# Altium schematic sheet Amplifier.SchDoc(Amplifier)
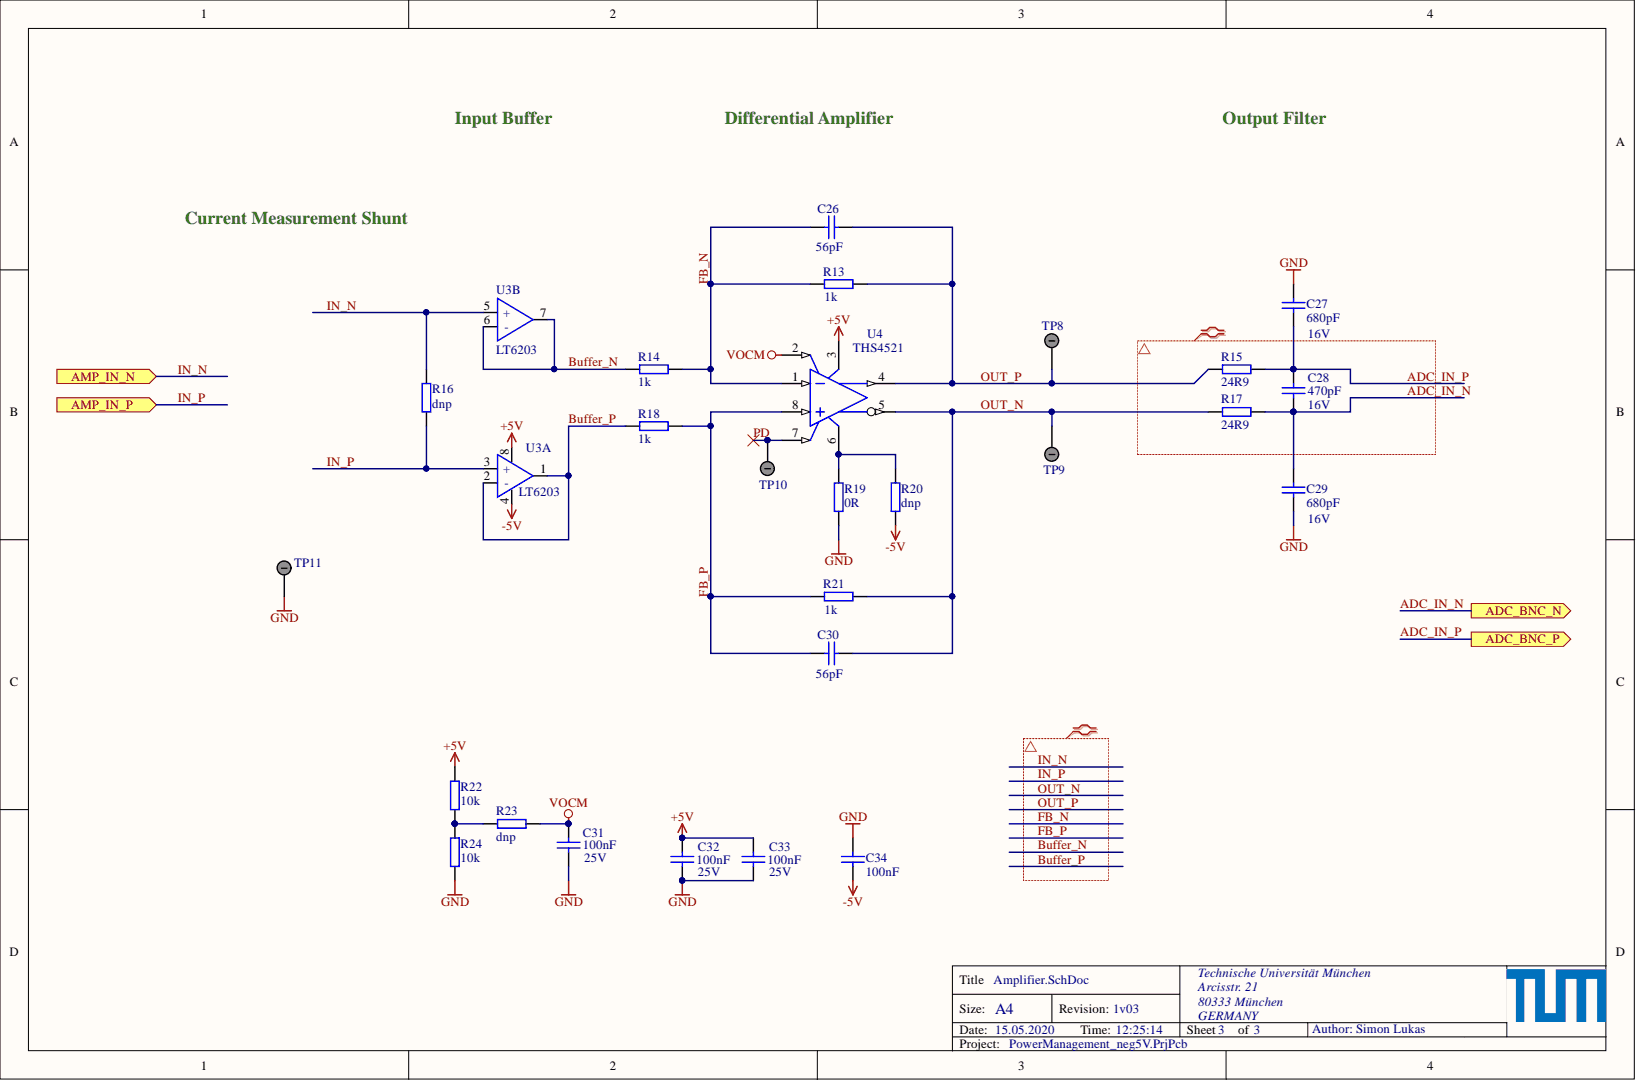
[diagram p0: Amplifier.SchDoc]

## Parts
C26  56pF  [CAPC1608X07N]
C27  680pF  Voltage: 16V  [CAPC1608X07N]
C28  470pF  Voltage: 16V  [CAPC1608X07N]
C29  680pF  Voltage: 16V  [CAPC1608X07N]
C30  56pF  [CAPC1608X07N]
C31  100nF  Voltage: 25V  [CAPC1608X07N]
C32  100nF  Voltage: 25V  [CAPC1608X07N]
C33  100nF  Voltage: 25V  [CAPC1608X07N]
C34  100nF  [CAPC1608X07N]
R13  1k  [RESC1608X06N]
R14  1k  [RESC1608X06N]
R15  24R9  [RESC1608X06N]
R16  dnp  [RESC3216X65N]
R17  24R9  [RESC1608X06N]
R18  1k  [RESC1608X06N]
R19  0R  [RESC1608X06N]
R20  dnp  [RESC1608X06N]
R21  1k  [RESC1608X06N]
R22  10k  [RESC1608X06N]
R23  dnp  [RESC1608X06N]
R24  10k  [RESC1608X06N]
TP8  AMP+  [TP_5006]  (value not drawn)
TP9  AMP-  [TP_5006]  (value not drawn)
TP10  PD  [TP_5006]
TP11  GND  [TP_5006]
U3  LT6203  [MSOP8_3x3-8N]  (parts A,B)
U4  THS4521  [DGK0008A_N]

## Ports
ADC_BNC_N, ADC_BNC_P, AMP_IN_N, AMP_IN_P

## Nets
+5V: C32.1 C33.1 R22.1 U3.8 U4.3
-5V: C34.2 R20.1 U3.4
GND: C27.1 C29.2 C31.2 C32.2 C33.2 C34.1 R19.1 R24.2 TP11.1
VOCM: C31.1 R23.1 U4.2
ADC_IN_N: C28.2 C29.1 R17.2 ; port ADC_BNC_N
ADC_IN_P: C27.2 C28.1 R15.2 ; port ADC_BNC_P
Buffer_N: R14.1 U3.6(-) U3.7
Buffer_P: R18.1 U3.1 U3.2(-)
FB_N: C26.1 R13.1 R14.2 U4.1
FB_P: C30.1 R18.2 R21.1 U4.8
IN_N: R16.2 U3.5(+) ; port AMP_IN_N
IN_P: R16.1 U3.3(+) ; port AMP_IN_P
OUT_N: C30.2 R17.1 R21.2 TP9.1 U4.5
OUT_P: C26.2 R13.2 R15.1 TP8.1 U4.4
PD: TP10.1 U4.7
NetR19_2: R19.2 R20.2 U4.6
NetR22_2: R22.2 R23.2 R24.1
N00012:
N00013:

## Library data of the parts
C26 C30 = Cap_0603: MPN: VJ0603A560JXACW1BC; Voltage: 50V; MF: Vishay; Dielectric: C0G; Value: 56pF; Supplier Part Number 1: 77-VJ0603A560JXACBC; Supplier 1: Mouser; Package: 0603; Component Kind: Standard; Library Name: neg5V.SCHLIB
C27 C29 = Cap_0603: MPN: VJ0603A681GXJCW1BC; MF: Vishay; Dielectric: C0G; Value: 680pF; Supplier Part Number 1: 77-VJ0603A681GXJCBC; Supplier 1: Mouser; Package: 0603; Component Kind: Standard; Library Name: neg5V.SCHLIB
C28 = Cap_0603: MPN: VJ0603A471JXJCW1BC; MF: Vishay; Dielectric: C0G; Value: 470pF; Supplier Part Number 1: 77-VJ0603A471JXJCBC; Supplier 1: Mouser; Package: 0603; Component Kind: Standard; Library Name: neg5V.SCHLIB
C31-C33 = Cap_0603: MPN: 885012206071; MF: Würth; Dielectric: X7R; Value: 100nF; Supplier Part Number 1: 710-885012206071; Supplier 1: Mouser; Package: 0603; Component Kind: Standard; Library Name: neg5V.SCHLIB
C34 = Cap_0603: MPN: 885012206071; Voltage: 25V; MF: Würth; Dielectric: X7R; Value: 100nF; Supplier Part Number 1: 710-885012206071; Supplier 1: Mouser; Package: 0603; Component Kind: Standard; Library Name: neg5V.SCHLIB
R13 R14 R18 R21 = R_0603: MPN: CRCW06031K00JNEAC; MF: Vishay / Dale; Value: 1k; Supplier Part Number 1: 71-CRCW06031K00JNEAC; Supplier 1: Mouser; Package: 0603; Component Kind: Standard; Library Name: neg5V.SCHLIB
R15 R17 = R_0603: MPN: RC0603FR-1324R9L; MF: Yageo; Value: 24R9; Supplier Part Number 1: 603-RC0603FR-1324R9L; Supplier 1: Mouser; Package: 0603; Component Kind: Standard; Library Name: neg5V.SCHLIB
R16 = R_1206: WID SMD 1M 5% 0,25W; MPN: APC1206B200RN; Preferred: Yes; possilbeValue: 200R; Mixed: SMT; MF: ARCOL/Ohmite; AN: 22757; Value: dnp; Tolerance: 5%; Technology: TK100; Supplier Part Number 1: 284-APC1206B200RN; Supplier 1: Mouser; Component Kind: Standard; Case: 1206; Library Name: neg5V.SCHLIB
R19 = R_0603: MPN: CRCW06030000Z0EBC; MF: Vishay / Dale; Value: 0R; Supplier Part Number 1: 71-CRCW06030000Z0EBC; Supplier 1: Mouser; Package: 0603; Component Kind: Standard; Library Name: neg5V.SCHLIB
R20 R23 = R_0603: Value: dnp; Package: 0603; Component Kind: Standard; Library Name: neg5V.SCHLIB
R22 R24 = R_0603: MPN: CRCW060310K0FKEAC; MF: Vishay / Dale; Value: 10k; Supplier Part Number 1: 71-CRCW060310K0FKEAC; Supplier 1: Mouser; Package: 0603; Component Kind: Standard; Library Name: neg5V.SCHLIB
TP8-TP11 = 5006: Test Point;  Compact;  THM;  PC;  .350 L;  .125 OD;  Heat Resistant Nylon 46;  Black; Manufacturer: Keystone Electronics; Plating: Silver; Outside Diameter: 3.18mm; Number of Ports: 1; Inside Diameter: 1.78mm; Hole Diameter: 1.6mm; Body Material: PBT; Supplier Part Number 1: 534-5006; Supplier 1: Mouser; Stock 1: 203116; RoHS: Yes; REACH SVHC: No SVHC; Packaging: Bulk; Package Quantity: 100; Orientation: Straight; Mount: PCB,Through Hole; Material: Nylon,Bronze,Silver; Manufacturer Part Number: 5006; Length: 8.89mm; Lead Free: Lead Free; Housing Color: Black; Height: 0.35inch; Flammability Rating: UL94 V-0; Contact Plating: Tin,Silver; Contact Material: Bronze; ComponentLink5URL: https://datasheet.ciiva.com/16883/70182825-16883963.pdf?src-supplier=Allied+Electronics; ComponentLink5Description: https://datasheet.ciiva.com/16883/70182825-16883963.pdf?src-supplier=Allied+Electronics; ComponentLink4URL: https://datasheet.ciiva.com/2917/m60-2p60-2917629.pdf?src-supplier=Digi-Key; ComponentLink4Description: https://datasheet.ciiva.com/2917/m60-2p60-2917629.pdf?src-supplier=Digi-Key; ComponentLink3URL: https://datasheet.ciiva.com/11744/0900766b8009b7c7-11744947.pdf?src-supplier=RSComponents; ComponentLink3Description: https://datasheet.ciiva.com/11744/0900766b8009b7c7-11744947.pdf?src-supplier=RSComponents; ComponentLink2URL: https://datasheet.ciiva.com/1454/1718283-1454829.pdf?src-supplier=Element14; ComponentLink2Description: https://datasheet.ciiva.com/1454/1718283-1454829.pdf?src-supplier=Element14; ComponentLink1URL: https://datasheet.ciiva.com/1123/m60-2p60-1123808.pdf?src-supplier=Newark; ComponentLink1Description: https://datasheet.ciiva.com/1123/m60-2p60-1123808.pdf?src-supplier=Newark; Component Kind: Standard; Color: Black; Category: Connectors; Library Name: neg5V.SCHLIB
U3 = LT6203_MSOP8: 2 Ch Low Noise, Low Power OpAmp; MPN: LT6203CMS8#PBF; MF: Analog Devices / Linear Technology; Supplier Part Number 1: 584-LT6203CMS8#PBF; Supplier 1: Mouser; Package: MSOP-8; Component Kind: Standard; Library Name: neg5V.SCHLIB; Created: Kreppel_20190830
U4 = THS4521IDGKR: IC AMP RRO NEG OUT VLP 8VSSOP (Single Channel fully differential Amplifier); Manufacturer: Texas Instruments; Voltage Gain: 119dB; Unity Gain Bandwidth Product: 95MHz; Slew Rate: 490V/µs; Power Supply Rejection Ratio (PSRR): 80dB; Output Current per Channel: 55mA; Operating Supply Voltage: 5V; Operating Supply Current: 1.14mA; Number of Elements: 1; Min Supply Voltage: 2.5V; Min Dual Supply Voltage: 1.5V; Max Supply Voltage: 5.5V; Max I/O Voltage: 2mV; Max Dual Supply Voltage: 2.75V; Input Bias Current: 900nA; Bandwidth: 145MHz; Weight: 0.000935oz; Supplier Part Number 1: 595-THS4521IDGKR; Supplier 1: Mouser; Stock 1: 12114; RoHS: Yes; REACH SVHC: No SVHC; Radiation Hardening: No; Pricing 1: 1=2,55000, 10=2,29000, 25=2,16000, 100=1,78000, 250=1,59000, 500=1,54000, 1000=1,28000, 2500=1,25000, 1=2,83000, 10=2,54000, 25=2,40000, 100=1,98000, 250=1,77000, 500=1,71000 (EUR); Packaging: Tape and Reel; Package Quantity: 2500; Number of Pins: 8; Number of Circuits: 1; Number of Channels: 1; Number of Amplifiers: 1; Nominal Supply Current: 1.14mA; Min Operating Temperature: -40°C; Max Operating Temperature: 85°C; Manufacturer Part Number: THS4521IDGKR; Library Name: neg5V.SCHLIB; Lead Free: Lead Free; Input Offset Voltage (Vos): 2mV; Gain Bandwidth Product: 95MHz; ComponentLink4URL: https://datasheet.ciiva.com/18622/ths4521-18622157.pdf?src-supplier=Digi-Key; ComponentLink4Description: https://datasheet.ciiva.com/18622/ths4521-18622157.pdf?src-supplier=Digi-Key; ComponentLink3URL: https://datasheet.ciiva.com/18622/ths4521-18622156.pdf?src-supplier=Digi-Key; ComponentLink3Description: https://datasheet.ciiva.com/18622/ths4521-18622156.pdf?src-supplier=Digi-Key; ComponentLink2URL: https://datasheet.ciiva.com/18622/ths4521-18622155.pdf?src-supplier=Digi-Key; ComponentLink2Description: https://datasheet.ciiva.com/18622/ths4521-18622155.pdf?src-supplier=Digi-Key; ComponentLink1URL: https://datasheet.ciiva.com/14456/sbos458f-14456592.pdf?src-supplier=Avnet; ComponentLink1Description: https://datasheet.ciiva.com/14456/sbos458f-14456592.pdf?src-supplier=Avnet; Component Kind: Standard; Common Mode Rejection Ratio: 80dB; Category: Amplifiers - Op Amps, Buffer, Instrumentation; Case/Package: VSSOP; -3db Bandwidth: 145MHz
